# Altium schematic sheet TemperatureSensor_CAN_ESP32.SchDoc(TemperatureSensor_CAN_ESP32)
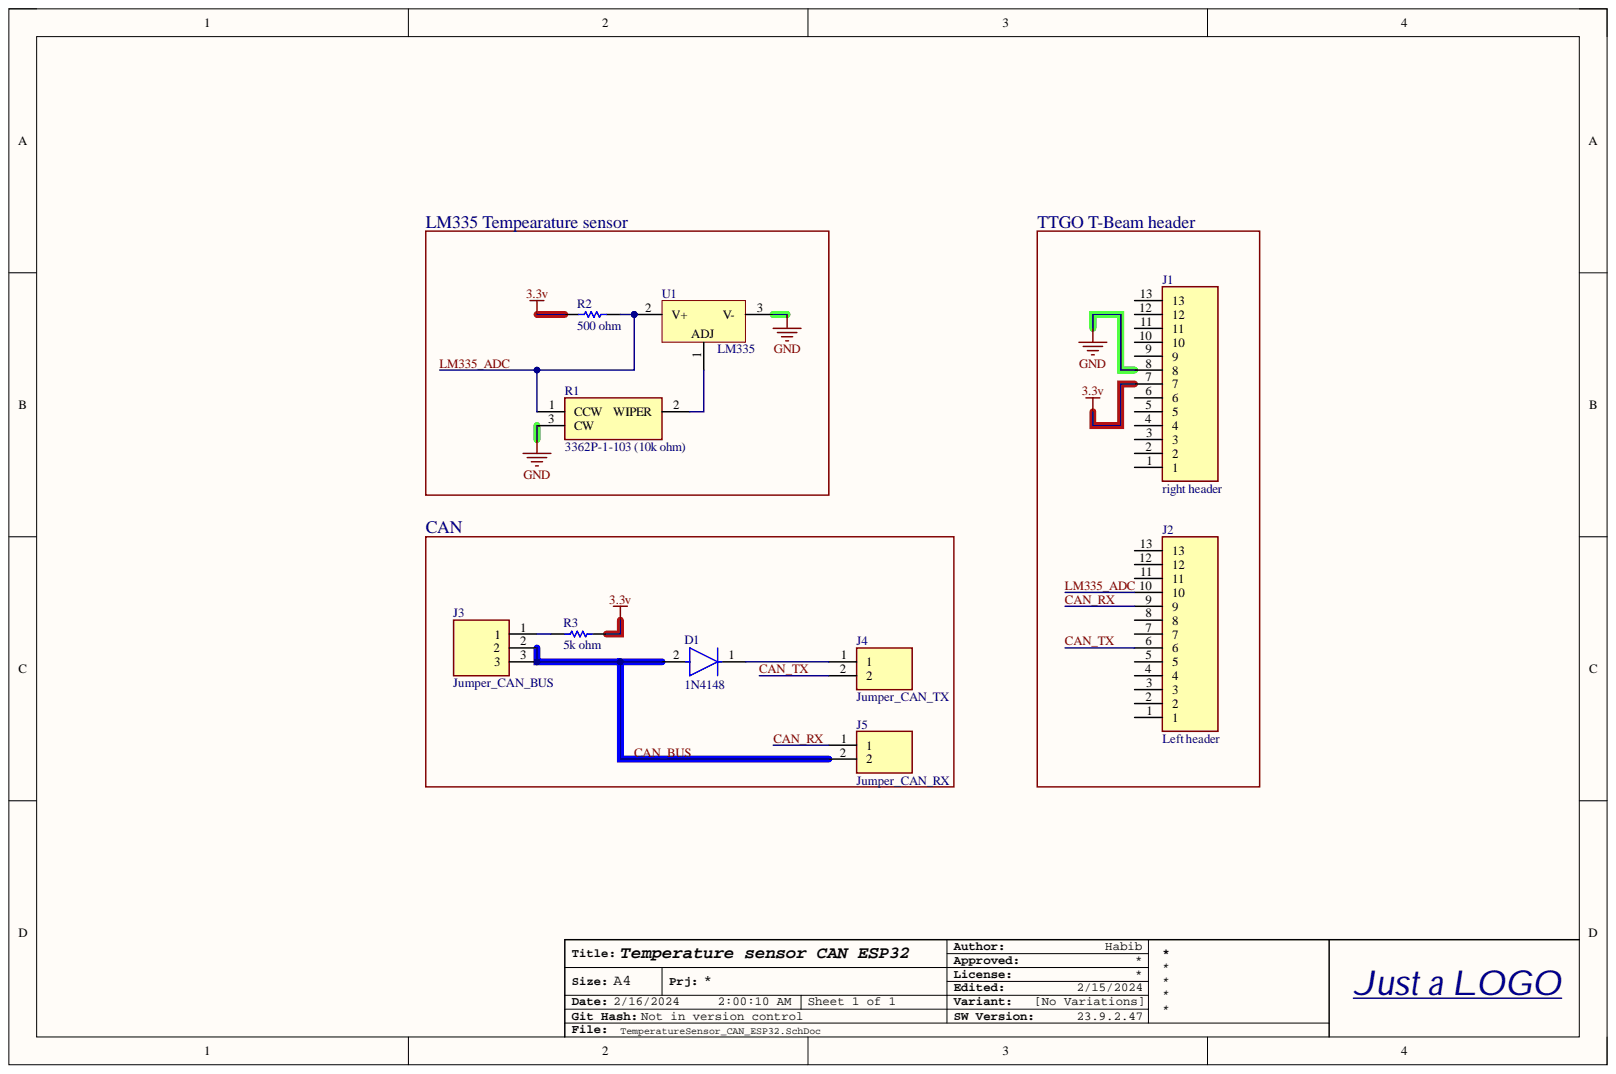
[diagram p0: TemperatureSensor_CAN_ESP32.SchDoc]

## Parts
D1  1N4148  [FP-017AG-MFG]
J1  right header  [SS-113-XX-22]
J2  Left header  [SS-113-XX-22]
J3  Jumper_CAN_BUS  [HDRV3W66P0X254_1X3_762X249X1041P]
J4  Jumper_CAN_TX  [HDRV2W66P0X254_1X2_508X249X1067P]
J5  Jumper_CAN_RX  [HDRV2W66P0X254_1X2_508X249X1067P]
R1  3362P-1-103 (10k ohm)  [3362P_1]
R2  500 ohm  [OMTE-RES7X2.5-2]
R3  5k ohm  [OMTE-RES7X2.5-2]
U1  LM335  [LP0003A]

## Nets
3.3v: J1.7 R2.1 R3.2
GND: J1.8 R1.3 U1.3
CAN_BUS: D1.2 J3.2 J3.3 J5.2
CAN_RX: J2.9 J5.1
CAN_TX: J2.6 J4.2
LM335_ADC: J2.10 R1.1 R2.2 U1.2
NetD1_1: D1.1 J4.1
NetJ3_1: J3.1 R3.1
NetR1_2: R1.2 U1.1

Not connected: J1 1 2 3 4 5 6 9 10 11 12 13; J2 1 2 3 4 5 7 8 11 12 13

## Library data of the parts
D1 = CMP-07163-000046-1: DIODE GEN PURP 100V 200MA DO35; Capacitance: 4pF; Current: 4A; Element Configuration: Single; Forward Current: 300mA; Forward Voltage: 1V; Max Forward Surge Current (Ifsm): 1A; Max Power Dissipation: 500mW; Max Reverse Current: 5uA; Outside Diameter: 1.85mm; Polarity: Standard; Recovery Time: 4ns; Average Rectified Current: 200mA; Case/Package: DO-35; Component Kind: Standard; Contact Plating: Tin; Current Rating: 200mA; Diameter: 1.91mm; Height: 4.56mm; Lead Free: Lead Free; Length: 4.56mm; Library Name: Altium Content Vault; Max Operating Temperature: 175°C; Max Repetitive Reverse Voltage (Vrrm): 100V; Max Reverse Voltage (DC): 100V; Max Surge Current: 4A; Min Operating Temperature: -65°C; Mount: Through Hole; Number of Pins: 2; Output Current: 200mA; Package Quantity: 2000; Packaging: Bulk; Peak Non-Repetitive Surge Current: 4A; Power Dissipation: 500mW; Radiation Hardening: No; REACH SVHC: No SVHC; Reverse Recovery Time: 4ns; Reverse Voltage: 100V; Series: -; Voltage: 100V; Voltage Rating (DC): 100V; Weight: 0.01lb; Width: 1.91mm
J1 J2 = SS-113-T-22: Connector; Manufacturer_Name: SAMTEC; Component Kind: Standard; Datasheet Link: http://suddendocs.samtec.com/prints/sx-1xx-xx-xx-x-x-mkt.pdf; Height: 4.44mm; Manufacturer_Part_Number: SS-113-T-22
J3 = 22-28-4031: Connector; Manufacturer_Name: Molex; Component Kind: Standard; Datasheet Link: https://www.molex.com/pdm_docs/sd/022284033_sd.pdf; Height: 10.41mm; Manufacturer_Part_Number: 22-28-4031; Mouser Part Number: 538-22-28-4031; Mouser Price/Stock: https://www.mouser.co.uk/ProductDetail/Molex/22-28-4031?qs=PcTKi%252B0N2SeG%2FDValJnqMA%3D%3D
J4 J5 = 22-28-4027: Connector; Manufacturer_Name: Molex; Component Kind: Standard; Datasheet Link: https://rs.componentsearchengine.com/Datasheets/1/22-28-4027.pdf; Height: 10.67mm; Manufacturer_Part_Number: 22-28-4027; Mouser Part Number: 538-22-28-4027; Mouser Price/Stock: https://www.mouser.co.uk/ProductDetail/Molex/22-28-4027?qs=MuwpYaHj750%2FJoCgIA915Q%3D%3D
R1 = 3362P-1-103: Resistor; Manufacturer_Name: Bourns; Arrow Part Number: 3362P-1-103; Arrow Price/Stock: https://www.arrow.com/en/products/3362p-1-103/bourns?region=nac; Component Kind: Standard; Datasheet Link: http://www.bourns.com/docs/Product-Datasheets/3362.pdf; Manufacturer_Part_Number: 3362P-1-103; Mouser Part Number: 652-3362P-1-103; Mouser Price/Stock: https://www.mouser.co.uk/ProductDetail/Bourns/3362P-1-103?qs=Uf%2FsSBgPTJJhIGut%2FdpuEA%3D%3D
R2 R3 = CMP-2000-06865-1: Little Demon(R) Carbon Composition Molded OD Series, 250 V, 10 KOhm, +/-5%, -70 to 130 degC, 2-Pin THD, RoHS, Bulk; Manufacturer: Ohmite; PartNumber: OD103JE; Catalog Drawings: OD,OF,OASeries; EDA / CAD Models: DownloadfromAcceleratedDesigns; Online Catalog: OD,OF,OASeries,LittleDemon®; Power (Watts): 0.25W,1/4W; Power Rating: 0.25W; Resistance (Ohms): 10k; Tolerance: ±5%; Category: Resistors; Component Kind: Standard; Composition: CarbonComposition; Datasheet URL: http://www.ohmite.com/cat/res_od_of_oa.pdf; Datasheet Version: 06/2016; Family: ThroughHoleResistors; Features: PulseWithstanding; Height: -; Library Name: Altium Content Vault; Manufacturer URL: http://www.ohmite.com/; Mounting Technology: ThroughHole; Number of Terminations: 2; Operating Temperature: -; Package / Case: Axial; Package Description: 2-Pin THD Resistor, Body 7 x 2.5 mm, Lead Dia. 0.6 mm; Package Reference: RES7X2.5; Packaging: Bulk; RoHS Information: LittleDemon"E"SeriesMaterialDeclaration; Series: LittleDemon®OD; Size / Dimension: 0.098"Diax0.276"L(2.50mmx7.00mm); Standard Package: 100; Supplier Device Package: Axial; Temperature Coefficient: -
U1 = CMP-0066-00016-3: Precision Temperature Sensor, 3-pin TO-92, Pb-Free; Manufacturer: Texas Instruments; CompType: Temp Sensor IC; PackageTopMarking: NSXYTT*LM335*Z; PowerWise: N; Code_JEDEC: TO-226-AA; Component Kind: Standard; ComponentLink1Description: Datasheet URL; ComponentLink1URL: http://www.ti.com/general/docs/lit/getliterature.tsp?genericPartNumber=LM335&fileType=pdf; Library Name: Altium Content Vault; PackageDescription: TO-92, Molded, 3 Lead; PackageReference: LP0003A; PinCount: 3; RoHS: Y
